# Altium schematic sheet POWERSTAGE.SchDoc(POWERSTAGE)
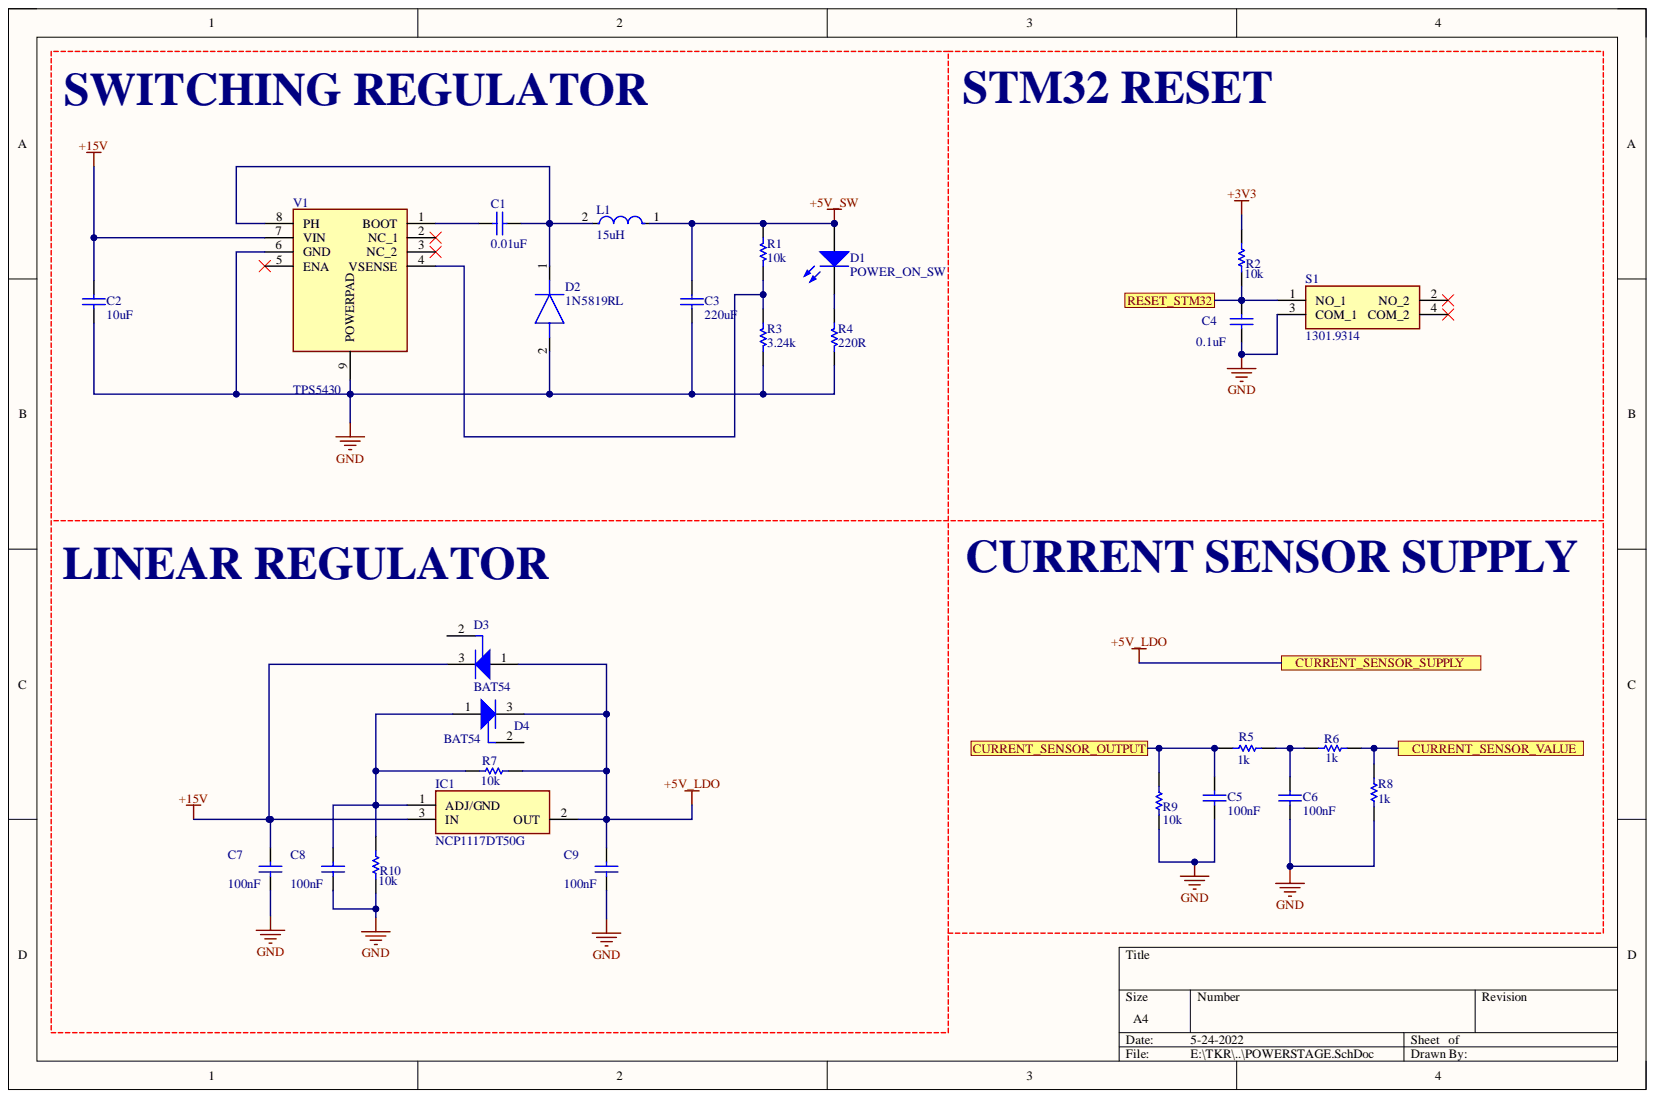
[diagram p0: POWERSTAGE.SchDoc]

## Parts
C1  0.01uF  [KEMT-1206-2_N]
C2  10uF  [KEMT-1206-2_N]
C3  220uF  [KEMT-1206-2_N]
C4  0.1uF  [KEMT-1206-2_N]
C5  100nF  [KEMT-1206-2_N]
C6  100nF  [KEMT-1206-2_N]
C7  100nF  [KEMT-1206-2_N]
C8  100nF  [KEMT-1206-2_N]
C9  100nF  [KEMT-1206-2_N]
D1  POWER_ON_SW  [3.2X1.6X1.1]
D2  1N5819RL  [DIOAD1414W86L464D238]
D3  BAT54  [INF-SOT23_N]
D4  BAT54  [INF-SOT23_N]
IC1  NCP1117DT50G  [LM317MADTRKG]
L1  15uH  [FP-IR02-MFG]
R1  10k  [RESC3216X60X45NL10T20 - duplicate]
R2  10k  [RESC3216X60X45NL10T20 - duplicate]
R3  3.24k  [RESC3216X60X45NL10T20 - duplicate]
R4  220R  [RESC3216X60X45NL10T20 - duplicate]
R5  1k  [R1206]
R6  1k  [R1206]
R7  10k  [RESC3216X60X45NL10T20 - duplicate]
R8  1k  [R1206]
R9  10k  [R1206]
R10  10k  [RESC3216X60X45NL10T20 - duplicate]
S1  1301.9314  [13019319]
V1  TPS5430  [TPS5430]

## Ports
CURRENT_SENSOR_OUTPUT, CURRENT_SENSOR_SUPPLY, CURRENT_SENSOR_VALUE, RESET_STM32

## Nets
+3V3: R2.2
+5V_SW: C3.2 D1.1 L1.1 R1.2
+15V: C2.2 C7.1 D3.3 IC1.3 V1.7(VIN)
GND: C2.1 C3.1 C4.1 C5.1 C6.1 C7.2 C8.2 C9.2 D2.2 R3.1 R4.1 R8.2 R9.2 R10.1 S1.3(COM_1) V1.6(GND) V1.9(POWERPAD)
+5V_LDO: C9.1 D3.1 D4.3 IC1.2 R7.1 ; port CURRENT_SENSOR_SUPPLY
CURRENT_SENSOR_OUTPUT: C5.2 R5.2 R9.1 ; port CURRENT_SENSOR_OUTPUT
CURRENT_SENSOR_VALUE: R6.1 R8.1 ; port CURRENT_SENSOR_VALUE
RESET_STM32: C4.2 R2.1 S1.1(NO_1) ; port RESET_STM32
NetC1_1: C1.1 D2.1 L1.2 V1.8(PH)
NetC1_2: C1.2 V1.1(BOOT)
NetC6_2: C6.2 R5.1 R6.2
NetC8_1: C8.1 D4.1 IC1.1 R7.2 R10.2
NetD1_2: D1.2 R4.2
NetR1_1: R1.1 R3.2 V1.4(VSENSE)

Not connected: D3 2; D4 2; S1 2(NO_2) 4(COM_2); V1 2(NC_1) 3(NC_2) 5(ENA)

## Library data of the parts
C1-C4 = CAPACITOR: CAP, Ceramic, 0.1 uF, +/- 10%, 50 V, -55 to 125 degC, 1206 (3216 Metric), RoHS, Tape and Reel; Manufacturer: Kemet; Capacitance: 0.1µF; Capacitor Case Style: 1206[3216Metric]; Dielectric Characteristic: X7R; Product Range: KEMET-CSeries; SVHC: ToBeAdvised; Voltage Rating: 50V; Capacitance Tolerance: ±10%; Component Kind: Standard; Datasheet URL: https://datasheet.ciiva.com/6125/1725438-6125494.pdf; Datasheet Version: Jul-13; Manufacturer URL: http://www.kemet.com/; Operating Temperature Max: 125degC; Operating Temperature Min: -55degC; Package Description: 2-Leads SMD, Body 3.2 x 1.6 mm; Package Reference: 1206-2; Packaging: Tape&Reel; Library Name: Regular_used_components_2D_Symbol.SchLib
C5-C9 = CMP-1037-04202-2: CAP, Ceramic, 0.1 uF, +/- 10%, 50 V, -55 to 125 degC, 1206 (3216 Metric), RoHS, Tape and Reel; Manufacturer: Kemet; Capacitance: 0.1µF; Capacitor Case Style: 1206[3216Metric]; Dielectric Characteristic: X7R; Product Range: KEMET-CSeries; SVHC: ToBeAdvised; Voltage Rating: 50V; Capacitance Tolerance: ±10%; Component Kind: Standard; Datasheet URL: https://datasheet.ciiva.com/6125/1725438-6125494.pdf; Datasheet Version: Jul-13; Manufacturer URL: http://www.kemet.com/; Operating Temperature Max: 125degC; Operating Temperature Min: -55degC; Package Description: 2-Leads SMD, Body 3.2 x 1.6 mm; Package Reference: 1206-2; Packaging: Tape&Reel; Library Name: Schlib1_TSAL_LV_3.1.SchLib
D1 = LED2: Typical RED, GREEN, YELLOW, AMBER GaAs LED; Component Kind: Standard; LatestRevisionDate: 17-Jul-2002; LatestRevisionNote: Re-released for DXP Platform.; Library Name: Miscellaneous Devices.IntLib; PackageDescription: SM LED; 2 Flat Leads; PackageReference: 3.2X1.6X1.1; PackageVersion: Feb-2002; Published: 8-Jun-2000; Simulation: LED2; Publisher: Altium Limited
D2 = 1N5819RL: Diode; Manufacturer_Name: STMicroelectronics; Arrow Part Number: 1N5819RL; Arrow Price/Stock: https://www.arrow.com/en/products/1n5819rl/stmicroelectronics?region=europe; Component Kind: Standard; Datasheet Link: http://www.st.com/web/en/resource/technical/document/datasheet/CD00001625.pdf; Manufacturer_Part_Number: 1N5819RL; Mouser Part Number: 511-1N5819-TR; Mouser Price/Stock: https://www.mouser.co.uk/ProductDetail/STMicroelectronics/1N5819RL?qs=mRqUSD7FX17%2FYMiCEfKDjA%3D%3D
D3 D4 = CMP-1182-00010-1: Silicon Schottky Diode, SOT23, Reel, Green; Manufacturer: Infineon; Configuration: Single; CT (max): 10Â pF; Green: TRUE; IF (max): 200Â mA; VF (max): 800Â mV; VR (max): 30Â V; Component Kind: Standard; ComponentLink1Description: Manufacturer URL; ComponentLink1URL: http://www.infineon.com/; ComponentLink2Description: Datasheet; ComponentLink2URL: http://www.infineon.com/dgdl/bat54series.pdf?folderId=db3a30431400ef68011425f1ca2505e3&fileId=db3a304314dca3890115190b74210ea6; ComponentLink3Description: Package Specification; ComponentLink3URL: http://www.infineon.com/dgdl/SOT23-Package_Overview.pdf?folderId=db3a30431936bc4b0119532290053c66&fileId=db3a30431936bc4b0119533468123c85; Library Name: Schlib1.SchLib; Mounting Technology: Surface Mount; PackageDescription: 3-Pin Small Outline Transistor Package, 1.3 x 2.9 mm Body, 0.95 mm Pitch; PackageReference: SOT23; PackageVersion: Apr-08; Packing: Reel; PartNumber: BAT54
IC1 = NCP1117DT50G: Integrated Circuit; Manufacturer_Name: onsemi; Arrow Part Number: NCP1117DT50G; Arrow Price/Stock: https://www.arrow.com/en/products/ncp1117dt50g/on-semiconductor?region=europe; Component Kind: Standard; Datasheet Link: https://componentsearchengine.com/Datasheets/2/NCP1117DT50G.pdf; Height: 2.38mm; Manufacturer_Part_Number: NCP1117DT50G; Mouser Part Number: 863-NCP1117DT50G; Mouser Price/Stock: https://www.mouser.co.uk/ProductDetail/onsemi/NCP1117DT50G?qs=Gev%252BmEvV0iaXyZQB7E2Yhg%3D%3D
L1 = CMP-03630-000001-1: IR-2 10 10% B08; Manufacturer: Vishay Dale; Manufacturer Part Number: IR02BH100K; Obsolete Part: true; Core Material: Iron; Inductance: 10uH; Q Factor: 55; Saturation Current: 130mA; Self Resonant Frequency: 50MHz; Series Resistance: 3.7Ω; Test Frequency: 7.9MHz; Value: 10uH; Automotive: No; Category: Ind; Component Kind: Standard; ComponentLink2Description: Datasheet; ComponentLink2URL: https://datasheet.ciiva.com/8536/ir-8536517.pdf; Device Class L1: Passive Components; Device Class L2: Inductors; Device Class L3: Fixed Inductors; Digikey Description: IR-2 10 10% B08; Height: 3.05mm; Ipc Land Pattern Name: CAPAD1147W70L838D305; Lead Free: Yes; Mouser Description: Fixed Inductors 10uH 10%; Octopart: https://octopart.com/ir02bh100k-vishay+dale-39675774; Package: IR02; RoHS: TRUE; Temperature: -55°C to +105°C; Temperature Range High: +105°C; Temperature Range Low: -55°C; Tolerance: 10%; Library Name: Regular_used_components_2D_Symbol.SchLib
R1-R4 R7 R10 = CMP-2003-02213-1: Manufacturer: Vishay Dale; Part Number: CRCW120647K0FKEA; Component Kind: Standard; Library Name: Regular_used_components_2D_Symbol.SchLib
R5 R6 R8 R9 = RES: Manufacturer: Vishay Dale; Part Number: CRCW120647K0FKEA; Component Kind: Standard; Library Name: SamacSys.SchLib
S1 = 1301.9314: Switch; Manufacturer_Name: SCHURTER; Arrow Part Number: 1301.9314; Arrow Price/Stock: https://www.arrow.com/en/products/1301.9314/schurter?region=europe; Component Kind: Standard; Datasheet Link: http://www.mouser.com/datasheet/2/358/typ_6x6_mm_tact_switches-1275689.pdf; Height: 9mm; Manufacturer_Part_Number: 1301.9314; Mouser Part Number: 693-1301.9314; Mouser Price/Stock: https://www.mouser.co.uk/ProductDetail/Schurter/13019314?qs=tGaZrb6HVSbFYC4o6ZpHBA%3D%3D
V1 = TPS5430: Component Kind: Standard; Library Name: Schlib1.SchLib
